# Altium schematic sheet On_Off.SchDoc("On Off Controller")
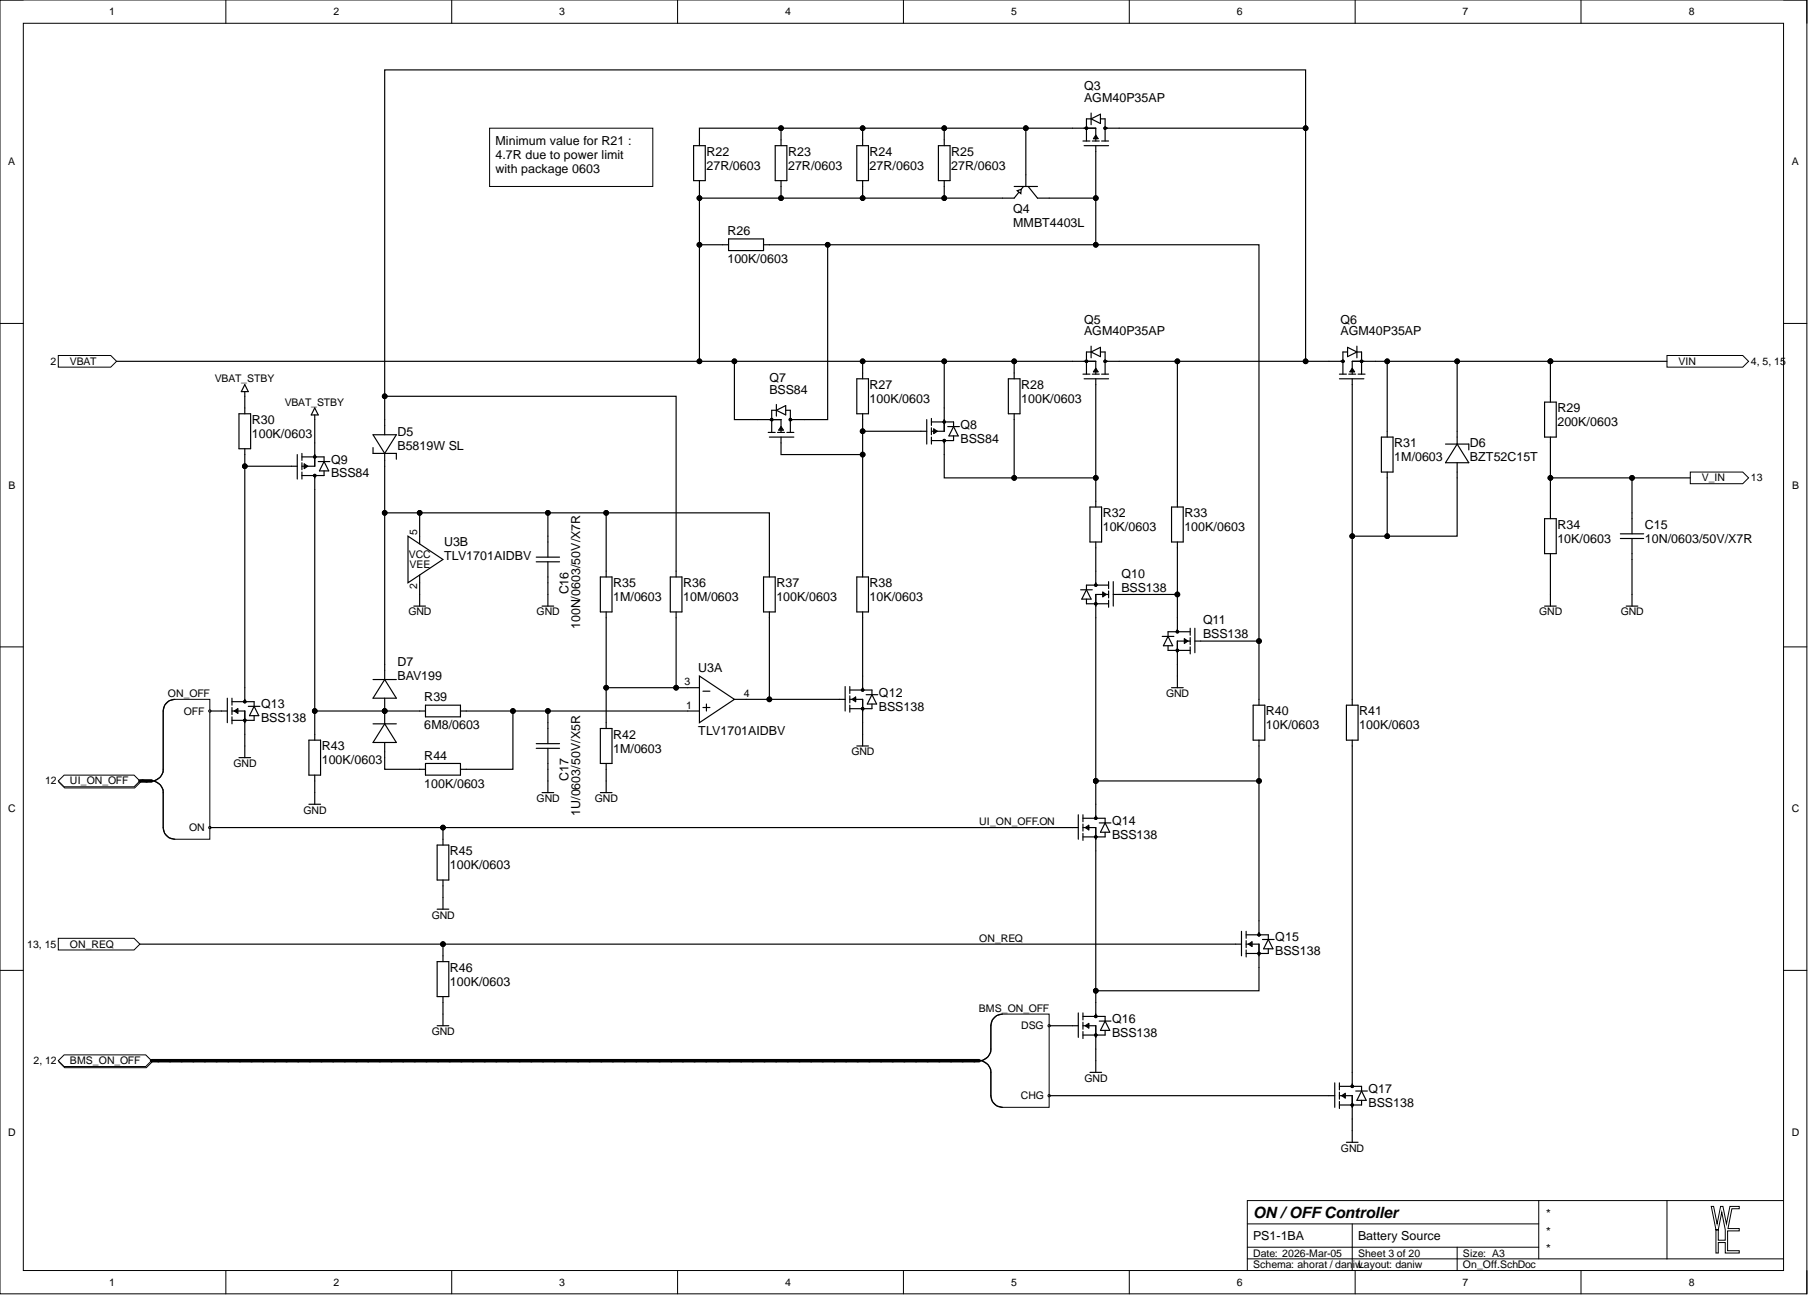
[diagram p0: On_Off.SchDoc]

## Parts
C15  10N/0603/50V/X7R  [CAP0603_X7R]
C16  100N/0603/50V/X7R  [CAP0603_X7R]
C17  1U/0603/50V/X5R  [CAP0603_X7R]
D5  B5819W SL  [SOD3718X125]
D6  BZT52C15T  [SOD1608X065]
D7  BAV199  [SOT2395P280X125-3-SE]
Q3  AGM40P35AP  [SON065P330X330X075-5-TH245X175]
Q4  MMBT4403L  [SOT2395P280X125-3-SE]
Q5  AGM40P35AP  [SON065P330X330X075-5-TH245X175]
Q6  AGM40P35AP  [SON065P330X330X075-5-TH245X175]
Q7  BSS84  [SOT2395P280X125-3-SE]
Q8  BSS84  [SOT2395P280X125-3-SE]
Q9  BSS84  [SOT2395P280X125-3-SE]
Q10  BSS138  [SOT2395P280X125-3-SE]
Q11  BSS138  [SOT2395P280X125-3-SE]
Q12  BSS138  [SOT2395P280X125-3-SE]
Q13  BSS138  [SOT2395P280X125-3-SE]
Q14  BSS138  [SOT2395P280X125-3-SE]
Q15  BSS138  [SOT2395P280X125-3-SE]
Q16  BSS138  [SOT2395P280X125-3-SE]
Q17  BSS138  [SOT2395P280X125-3-SE]
R22  27R/0603  [R0603-0.40-WW]
R23  27R/0603  [R0603-0.40-WW]
R24  27R/0603  [R0603-0.40-WW]
R25  27R/0603  [R0603-0.40-WW]
R26  100K/0603  [R0603-0.40-WW]
R27  100K/0603  [R0603-0.40-WW]
R28  100K/0603  [R0603-0.40-WW]
R29  200K/0603  [R0603-0.40-WW]
R30  100K/0603  [R0603-0.40-WW]
R31  1M/0603  [R0603-0.40-WW]
R32  10K/0603  [R0603-0.40-WW]
R33  100K/0603  [R0603-0.40-WW]
R34  10K/0603  [R0603-0.40-WW]
R35  1M/0603  [R0603-0.40-WW]
R36  10M/0603  [R0603-0.40-WW]
R37  100K/0603  [R0603-0.40-WW]
R38  10K/0603  [R0603-0.40-WW]
R39  6M8/0603  [R0603-0.40-WW]
R40  10K/0603  [R0603-0.40-WW]
R41  100K/0603  [R0603-0.40-WW]
R42  1M/0603  [R0603-0.40-WW]
R43  100K/0603  [R0603-0.40-WW]
R44  100K/0603  [R0603-0.40-WW]
R45  100K/0603  [R0603-0.40-WW]
R46  100K/0603  [R0603-0.40-WW]
U3  TLV1701AIDBV  [SOT2395P280X125-5-NW]  (parts A,B)

## Ports
BMS_ON_OFF, BMS_ON_OFF.CHG, BMS_ON_OFF.DSG, ON_REQ, UI_ON_OFF, UI_ON_OFF.OFF, UI_ON_OFF.ON, V_IN, VBAT, VIN

## Nets
GND: C15.2 C16.2 C17.2 Q11.2 Q12.2 Q13.2 Q16.2 Q17.2 R34.2 R42.2 R43.2 R45.2 R46.2 U3.2
VBAT_STBY: Q9.2 R30.1
ON_REQ: Q15.1 R46.1 ; port ON_REQ
UI_ON_OFF: Q13.1 ; port UI_ON_OFF
UI_ON_OFF.ON: Q14.1 R45.1 ; port UI_ON_OFF
V_IN: C15.1 R29.2 R34.1 ; port V_IN
VBAT: Q4.2 Q5.1,2,3 Q7.2 Q8.2 R22.1 R23.1 R24.1 R25.1 R26.1 R27.1 R28.1 ; port VBAT
VIN: D6.1 Q6.1,2,3 R29.1 R31.1 ; port VIN
NetC16_1: C16.1 D5.1 D7.2 R35.1 R37.1 U3.5
NetC17_1: C17.1 R39.2 R44.2 U3.1
NetD5_2: D5.2 Q3.5 Q5.5 Q6.5 R33.1 R36.1
NetD6_2: D6.2 Q6.4 R31.2 R41.1
NetD7_1: D7.1 R44.1
NetD7_3: D7.3 Q9.3 R39.1 R43.1
NetQ3_1,2,3: Q3.1,2,3 Q4.1 R22.2 R23.2 R24.2 R25.2
NetQ3_4: Q3.4 Q4.3 Q7.3 Q11.1 R26.2 R40.1
NetQ5_4: Q5.4 Q8.3 R28.2 R32.1
NetQ7_1: Q7.1 Q8.1 R27.2 R38.1
NetQ9_1: Q9.1 Q13.3 R30.2
NetQ10_1: Q10.1 Q11.3 R33.2
NetQ10_2: Q10.2 Q14.3 Q15.3 R40.2
NetQ10_3: Q10.3 R32.2
NetQ12_1: Q12.1 R37.2 U3.4
NetQ12_3: Q12.3 R38.2
NetQ14_2: Q14.2 Q15.2 Q16.3
NetQ16_1: Q16.1 ; port BMS_ON_OFF
NetQ17_1: Q17.1 ; port BMS_ON_OFF
NetQ17_3: Q17.3 R41.2
NetR35_2: R35.2 R36.2 R42.1 U3.3

## Library data of the parts
C15 = 10N/0603/50V/X7R: CAP SM 0603 10nF 50V X7R; Manufacturer: GENERIC; Manufacturer 1: YAGEO; Manufacturer Part Number 1: CC0603KPX7R9BB103; Absolute Value: 1E-8; Database Table Name: C$; Eurocircuits GPN: GPC0603103; Component Kind: Standard; HelpURL: https://www.yageo.com/upload/media/product/app/datasheet/mlcc/upy-gphc_x7r_6_3v-to-250v.pdf; Manufacturer 2: YAGEO; Manufacturer Part Number 2: CC0603KRX7R9BB103; Manufacturer Part Number 3: CC0603KRX7R9BB103; Package: 0603; Part Number: 10N/0603/50V/X7R; Supplier 1: Digi-Key; Supplier 2: Digi-Key; Supplier 3: LCSC; Supplier Part Number 1: 311-1572-1-ND; Supplier Part Number 2: 311-1085-1-ND; Supplier Part Number 3: C100042; Value: 10nF; Library Name: daniw_altium_lib.DbLib
C16 = 100N/0603/50V/X7R: CAP SM 0603 100nF 50V X7R; Manufacturer: GENERIC; Manufacturer 1: YAGEO; Manufacturer Part Number 1: CC0603KPX7R9BB104; Absolute Value: 1E-7; Database Table Name: C$; Eurocircuits GPN: GPC0603104; Component Kind: Standard; HelpURL: https://www.yageo.com/upload/media/product/app/datasheet/mlcc/upy-gphc_x7r_6_3v-to-250v.pdf; JLC Type: Basic; Manufacturer 2: YAGEO; Manufacturer Part Number 2: CC0603MRX7R9BB104; Manufacturer Part Number 3: CC0603KRX7R9BB104; Package: 0603; Part Number: 100N/0603/50V/X7R; Supplier 1: Digi-Key; Supplier 2: Digi-Key; Supplier 3: LCSC; Supplier Part Number 1: 311-3567-1-ND; Supplier Part Number 2: 311-4110-1-ND; Supplier Part Number 3: C14663; Value: 100nF; Library Name: daniw_altium_lib.DbLib
C17 = 1U/0603/50V/X5R: CAP SM 0603 1.0uF 50V X5R; Manufacturer: GENERIC; Manufacturer 1: Samsung Electro-Mechanics; Manufacturer Part Number 1: CL10A105KB8NNNC; Absolute Value: 1E-6; Database Table Name: C$; Eurocircuits GPN: GPC0603105-X5R; Component Kind: Standard; HelpURL: https://mm.digikey.com/Volume0/opasdata/d220001/medias/docus/658/CL10A105KB8NNNC_Spec.pdf; JLC Type: Basic; Manufacturer Part Number 3: CL10A105KB8NNNC; Package: 0603; Part Number: 1U/0603/50V/X5R; Supplier 1: Digi-Key; Supplier 3: LCSC; Supplier Part Number 1: 1276-1860-1-ND; Supplier Part Number 3: C15849; Value: 1.0uF; Library Name: daniw_altium_lib.DbLib
D5 = B5819W SL: 40V 600mV@1A 1A SOD-123 Schottky Barrier Diodes; Manufacturer: GENERIC; Manufacturer 1: SMC Diode Solutions; Database Table Name: D$; Absolute Value: 40V; Component Kind: Standard; HelpURL: https://datasheet.lcsc.com/lcsc/2304140030_Jiangsu-Changjing-Electronics-Technology-Co---Ltd--B5819W-SL_C8598.pdf; JLC Type: Basic; Manufacturer 3: Jiangsu Changjing Electronics Technology Co., Ltd.; Manufacturer Part Number 1: B5819WSL; Manufacturer Part Number 3: B5819W SL; Package: SOD-123; Part Number: B5819W SL; Supplier 1: Digikey; Supplier 3: LCSC; Supplier Part Number 1: 1655-B5819WSLCT-ND; Supplier Part Number 3: C8598; Value: B5819W SL; Library Name: daniw_altium_lib.DbLib
D6 = BZT52C15T: DIODE ZENER 15V 100MW SOD523; Manufacturer: Micro Commercial Co; Manufacturer Part Number 1: BZT52C15T-TP; Database Table Name: D$; Absolute Value: 15 V; Component Kind: Standard; HelpURL: https://www.mccsemi.com/pdf/Products/BZT52C(B)2V4T-BZT52C(B)39T(SOD-523).pdf; Manufacturer 1: Micro Commercial Co; Manufacturer 2: Diodes Incorporated; Manufacturer 3: Diodes Incorporated; Manufacturer Part Number 2: BZT52C15T-7; Manufacturer Part Number 3: BZT52C15T-7; Package: SC-79, SOD-523; Part Number: BZT52C15T; Supplier 1: Digikey; Supplier 2: Digikey; Supplier 3: LCSC; Supplier Part Number 1: BZT52C15T-TPMSCT-ND; Supplier Part Number 2: BZT52C15T-7DICT-ND; Supplier Part Number 3: C460787; Value: BZT52C15T; Library Name: daniw_altium_lib.DbLib
D7 = BAV199: Silicon Low Leakage Diode 85V; Manufacturer: Infineon Technologies; Manufacturer Part Number 1: BAV199E6433HTMA1; Database Table Name: D$; Absolute Value: 85V; Component Kind: Standard; HelpURL: https://www.infineon.com/dgdl/bav199series.pdf?folderId=db3a30431400ef6801141c748874044e&fileId=db3a30431400ef6801141cba733104e5; Manufacturer 1: Infineon Technologies; Manufacturer 3: LRC; Manufacturer Part Number 3: LBAV199LT1G; Package: SOT23; Part Number: BAV199; Supplier 1: Digikey; Supplier 3: LCSC; Supplier Part Number 1: BAV199E6433HTMA1CT-ND; Supplier Part Number 3: C12740; Value: BAV199; Library Name: daniw_altium_lib.DbLib
Q3 Q5 Q6 = AGM40P35AP: 40V 35A 11mΩ@10V,15A 30W 1.7V 1 piece P-channel PDFN(3.3x3.3) MOSFETs ROHS; Manufacturer: AGM-Semi; Database Table Name: T$; Component Kind: Standard; HelpURL: https://www.lcsc.com/datasheet/lcsc_datasheet_2504101957_AGM-Semi-AGM40P35AP_C18221399.pdf; Manufacturer 3: AGM-Semi; Manufacturer Part Number 3: AGM40P35AP; Package: 8-PowerVDFN; Part Number: AGM40P35AP; Supplier 3: LCSC; Supplier Part Number 3: C18221399; Library Name: daniw_altium_lib.DbLib
Q4 = MMBT4403L: Switching Transistor PNP Silicon; Manufacturer: ON SEMI; Database Table Name: T$; Component Kind: Standard; HelpURL: https://www.onsemi.com/pdf/datasheet/mmbt4403lt1-d.pdf; JLC Type: Extended; Manufacturer 3: ONSEMI; Manufacturer Part Number 3: MMBT4403LT1G; Package: SOT23; Part Number: MMBT4403L; Supplier 3: LCSC; Supplier Part Number 3: C78864; Library Name: daniw_altium_lib.DbLib
Q7-Q9 = BSS84: 50V P-Channel MOSFET; Manufacturer: GENERIC; Manufacturer 1: Good-Ark Semiconductor; Database Table Name: T$; Component Kind: Standard; HelpURL: https://goodarksemi.com/docs/datasheets/mosfets/BSS84.pdf; JLC Type: Extended; Manufacturer 2: LRC; Manufacturer 3: Jiangsu Changjing Electronics Technology Co., Ltd.; Manufacturer Part Number 1: BSS84; Manufacturer Part Number 2: LBSS84LT1G; Manufacturer Part Number 3: BSS84; Package: SOT23; Part Number: BSS84; Supplier 1: Digi-Key; Supplier 2: LCSC; Supplier 3: LCSC; Supplier Part Number 1: 4786-BSS84CT-ND; Supplier Part Number 2: C8492; Supplier Part Number 3: C114481; Library Name: daniw_altium_lib.DbLib
Q10-Q17 = BSS138: N-Kanal 50 V 220mA (Ta) 350mW (Ta) Oberflächenmontage SOT-23; Manufacturer: GENERIC; Manufacturer 1: ANBON SEMICONDUCTOR (INT'L) LIMITED; Database Table Name: T$; Component Kind: Standard; HelpURL: https://mm.digikey.com/Volume0/opasdata/d220001/medias/docus/3037/BSS138.pdf; JLC Type: Extended; Manufacturer 2: FOSAN; Manufacturer 3: FOSAN; Manufacturer Part Number 1: BSS138; Manufacturer Part Number 2: BSS138; Manufacturer Part Number 3: BSS138; Package: SOT23; Part Number: BSS138; Supplier 1: Digi-Key; Supplier 2: LCSC; Supplier 3: LCSC; Supplier Part Number 1: 4530-BSS138CT-ND; Supplier Part Number 2: C2926186; Supplier Part Number 3: C2926186; Library Name: daniw_altium_lib.DbLib
R22-R25 = 27R/0603: RES SM 0603 27R; Manufacturer: GENERIC; Manufacturer 1: YAGEO; Manufacturer Part Number 1: RC0603FR-1327RL; Absolute Value: 27; Database Table Name: R$; Eurocircuits GPN: GPR060327R; Component Kind: Standard; HelpURL: https://www.yageogroup.com/content/datasheet/asset/file/PYU-RC_GROUP_51_ROHS_L; JLC Type: Extended; Manufacturer 2: YAGEO; Manufacturer 3: YAGEO; Manufacturer Part Number 2: RC0603FR-0727RL; Manufacturer Part Number 3: RC0603FR-0727RL; Package: 0603; Part Number: 27R/0603; Supplier 1: Digi-Key; Supplier 2: Digi-Key; Supplier 3: LCSC; Supplier Part Number 1: 13-RC0603FR-1327RLCT-ND; Supplier Part Number 2: 311-27.0HRCT-ND; Supplier Part Number 3: C137753; Value: 27R; Library Name: daniw_altium_lib.DbLib
R26-R28 R30 R33 R37 R41 R43-R46 = 100K/0603: RES SM 0603 100K; Manufacturer: GENERIC; Manufacturer 1: YAGEO; Manufacturer Part Number 1: RC0603FR-13100KL; Absolute Value: 100000; Database Table Name: R$; Eurocircuits GPN: GPR0603100K; Component Kind: Standard; HelpURL: https://www.yageogroup.com/content/datasheet/asset/file/PYU-RC_GROUP_51_ROHS_L; JLC Type: Basic; Manufacturer 2: YAGEO; Manufacturer 3: UNI-ROYAL(Uniroyal Elec); Manufacturer Part Number 2: RC0603FR-10100KL; Manufacturer Part Number 3: 0603WAF1003T5E; Package: 0603; Part Number: 100K/0603; Supplier 1: Digi-Key; Supplier 2: Digi-Key; Supplier 3: LCSC; Supplier Part Number 1: 13-RC0603FR-13100KLCT-ND; Supplier Part Number 2: 13-RC0603FR-10100KLCT-ND; Supplier Part Number 3: C25803; Value: 100K; Library Name: daniw_altium_lib.DbLib
R29 = 200K/0603: RES SM 0603 200K; Manufacturer: GENERIC; Manufacturer 1: YAGEO; Manufacturer Part Number 1: RC0603FR-13200KL; Absolute Value: 200000; Database Table Name: R$; Eurocircuits GPN: GPR0603200K; Component Kind: Standard; HelpURL: https://www.yageogroup.com/content/datasheet/asset/file/PYU-RC_GROUP_51_ROHS_L; JLC Type: Basic; Manufacturer 2: YAGEO; Manufacturer 3: UNI-ROYAL(Uniroyal Elec); Manufacturer Part Number 2: RC0603FR-10200KL; Manufacturer Part Number 3: 0603WAF2003T5E; Package: 0603; Part Number: 200K/0603; Supplier 1: Digi-Key; Supplier 2: Digi-Key; Supplier 3: LCSC; Supplier Part Number 1: 13-RC0603FR-13200KLCT-ND; Supplier Part Number 2: 13-RC0603FR-10200KLCT-ND; Supplier Part Number 3: C25811; Value: 200K; Library Name: daniw_altium_lib.DbLib
R31 R35 R42 = 1M/0603: RES SM 0603 1M; Manufacturer: GENERIC; Manufacturer 1: YAGEO; Manufacturer Part Number 1: RC0603FR-131ML; Absolute Value: 1000000; Database Table Name: R$; Eurocircuits GPN: GPR06031M; Component Kind: Standard; HelpURL: https://www.yageogroup.com/content/datasheet/asset/file/PYU-RC_GROUP_51_ROHS_L; JLC Type: Basic; Manufacturer 2: YAGEO; Manufacturer 3: UNI-ROYAL(Uniroyal Elec); Manufacturer Part Number 2: RC0603FR-101ML; Manufacturer Part Number 3: 0603WAF1004T5E; Package: 0603; Part Number: 1M/0603; Supplier 1: Digi-Key; Supplier 2: Digi-Key; Supplier 3: LCSC; Supplier Part Number 1: 13-RC0603FR-131MLCT-ND; Supplier Part Number 2: 13-RC0603FR-101MLCT-ND; Supplier Part Number 3: C22935; Value: 1M; Library Name: daniw_altium_lib.DbLib
R32 R34 R38 R40 = 10K/0603: RES SM 0603 10K; Manufacturer: GENERIC; Manufacturer 1: YAGEO; Manufacturer Part Number 1: RC0603FR-1310KL; Absolute Value: 10000; Database Table Name: R$; Eurocircuits GPN: GPR060310K; Component Kind: Standard; HelpURL: https://www.yageogroup.com/content/datasheet/asset/file/PYU-RC_GROUP_51_ROHS_L; JLC Type: Basic; Manufacturer 2: YAGEO; Manufacturer 3: UNI-ROYAL(Uniroyal Elec); Manufacturer Part Number 2: RC0603FR-1010KL; Manufacturer Part Number 3: 0603WAF1002T5E; Package: 0603; Part Number: 10K/0603; Supplier 1: Digi-Key; Supplier 2: Digi-Key; Supplier 3: LCSC; Supplier Part Number 1: 13-RC0603FR-1310KLCT-ND; Supplier Part Number 2: 13-RC0603FR-1010KLCT-ND; Supplier Part Number 3: C25804; Value: 10K; Library Name: daniw_altium_lib.DbLib
R36 = 10M/0603: RES SM 0603 10M; Manufacturer: GENERIC; Manufacturer 1: YAGEO; Manufacturer Part Number 1: RC0603FR-1310ML; Absolute Value: 10000000; Database Table Name: R$; Eurocircuits GPN: GPR060310M; Component Kind: Standard; HelpURL: https://www.yageogroup.com/content/datasheet/asset/file/PYU-RC_GROUP_51_ROHS_L; JLC Type: Basic; Manufacturer 2: YAGEO; Manufacturer 3: UNI-ROYAL(Uniroyal Elec); Manufacturer Part Number 2: RC0603FR-1010ML; Manufacturer Part Number 3: 0603WAF1005T5E; Package: 0603; Part Number: 10M/0603; Supplier 1: Digi-Key; Supplier 2: Digi-Key; Supplier 3: LCSC; Supplier Part Number 1: 13-RC0603FR-1310MLCT-ND; Supplier Part Number 2: 13-RC0603FR-1010MLCT-ND; Supplier Part Number 3: C7250; Value: 10M; Library Name: daniw_altium_lib.DbLib
R39 = 6M8/0603: RES SM 0603 6M8; Manufacturer: GENERIC; Manufacturer 1: YAGEO; Manufacturer Part Number 1: RC0603FR-076M8L; Absolute Value: 6800000; Database Table Name: R$; Eurocircuits GPN: GPR06036M8; Component Kind: Standard; HelpURL: https://www.yageogroup.com/content/datasheet/asset/file/PYU-RC_GROUP_51_ROHS_L; JLC Type: Extended; Manufacturer 3: FOJAN; Manufacturer Part Number 3: FRC0603F6804TS; Package: 0603; Part Number: 6M8/0603; Supplier 1: Digi-Key; Supplier 3: LCSC; Supplier Part Number 1: 13-RC0603FR-076M8LCT-ND; Supplier Part Number 3: C3013205; Value: 6M8; Library Name: daniw_altium_lib.DbLib
U3 = TLV1701 SOT23: TLV1701 2.2-V to 36-V, microPower Comparato; Manufacturer: Texas Instruments; Manufacturer Part Number 1: TLV1701AIDBVR; Database Table Name: Integrated$; Component Kind: Standard; HelpURL: https://www.ti.com/lit/ds/symlink/tlv1701.pdf; Manufacturer 1: Texas Instruments; Manufacturer 2: Texas Instruments; Manufacturer 3: Texas Instruments; Manufacturer Part Number 2: TLV1701QDBVRQ1; Manufacturer Part Number 3: TLV1701AIDBVR; Package: SOT23-5; Part Number: TLV1701 SOT23; Supplier 1: Digi-Key; Supplier 2: Digi-Key; Supplier 3: LCSC; Supplier Part Number 1: 296-40599-1-ND; Supplier Part Number 2: 296-47458-1-ND; Supplier Part Number 3: C130035; Library Name: daniw_altium_lib.DbLib
